# Altium schematic sheet 12-Power.SchDoc(12-Power)
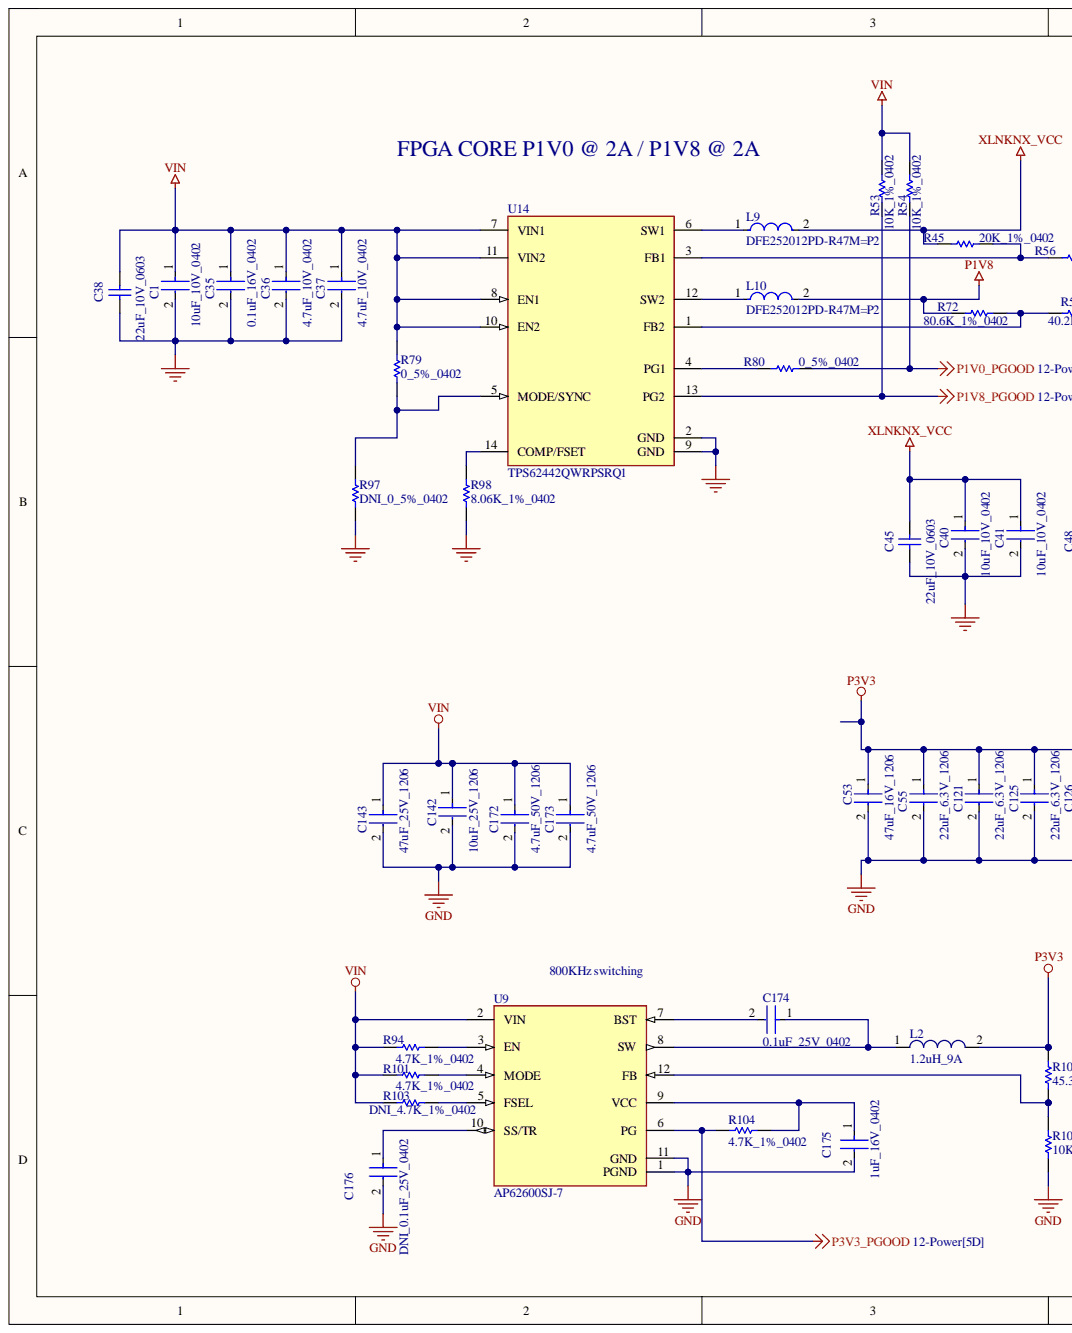
[diagram p0: 12-Power.SchDoc, left]
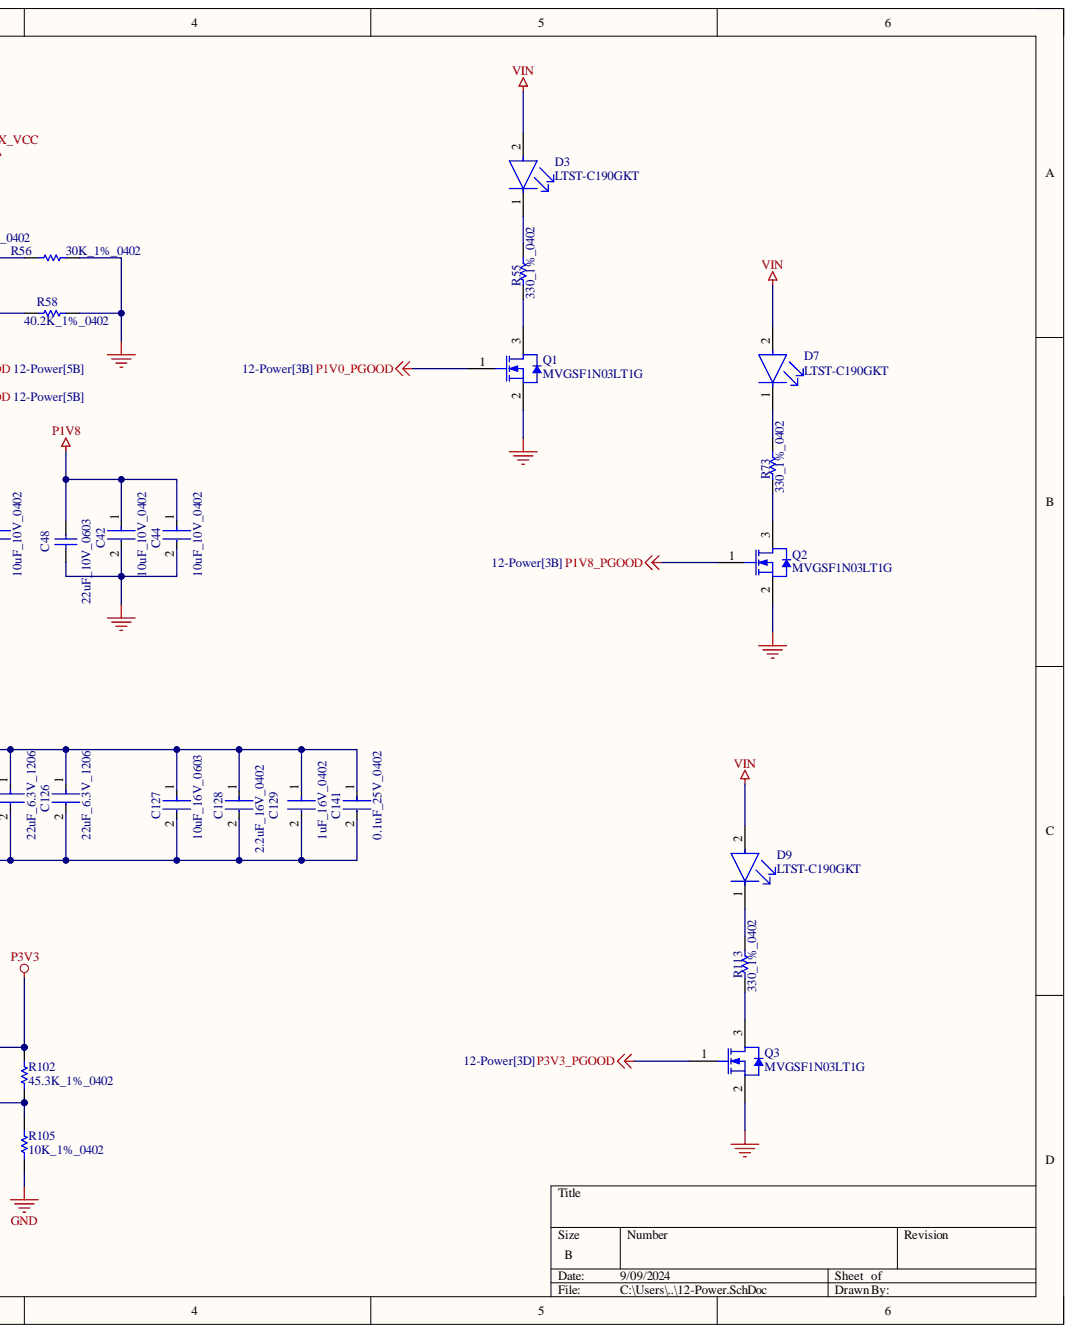
[diagram p1: 12-Power.SchDoc, right]

## Parts
C1  10uF_10V_0402  [FP-CL05A106MP5NUNC-MFG]
C35  0.1uF_16V_0402  [FP-C0402-BB-MFG]
C36  4.7uF_10V_0402  [FP-C1005-050-0_15-MFG]
C37  4.7uF_10V_0402  [FP-C1005-050-0_15-MFG]
C38  22uF_10V_0603  [CAPC1608X100X20ML10]
C40  10uF_10V_0402  [FP-CL05A106MP5NUNC-MFG]
C41  10uF_10V_0402  [FP-CL05A106MP5NUNC-MFG]
C42  10uF_10V_0402  [FP-CL05A106MP5NUNC-MFG]
C44  10uF_10V_0402  [FP-CL05A106MP5NUNC-MFG]
C45  22uF_10V_0603  [CAPC1608X100X20ML10]
C48  22uF_10V_0603  [CAPC1608X100X20ML10]
C53  47uF_16V_1206  [FP-C3216-160-0_2-MFG]
C55  22uF_6.3V_1206  [FP-1206-L_3_2_0_2-W_1_6_0-IPC_C]
C121  22uF_6.3V_1206  [FP-1206-L_3_2_0_2-W_1_6_0-IPC_C]
C125  22uF_6.3V_1206  [FP-1206-L_3_2_0_2-W_1_6_0-IPC_C]
C126  22uF_6.3V_1206  [FP-1206-L_3_2_0_2-W_1_6_0-IPC_C]
C127  10uF_16V_0603  [FP-0603-L_1_6_0_2-W_0_8_0-MFG]
C128  2.2uF_16V_0402  [FP-C1005-050-0_05-IPC_A]
C129  1uF_16V_0402  [FP-0402-L_1_0_0_05-W_0_5-IPC_A]
C141  0.1uF_25V_0402  [FP-0402-L_1_0_1-W_0_5_0_1-IPC_C]
C142  10uF_25V_1206  [FP-1206-L_3_2_0_2-W_1_6_0-IPC_A]
C143  47uF_25V_1206  [FP-C3216-160-0_2-MFG]
C172  4.7uF_50V_1206  [FP-GRM31C-0_2-e0_3_0_8-IPC_C]
C173  4.7uF_50V_1206  [FP-GRM31C-0_2-e0_3_0_8-IPC_C]
C174  0.1uF_25V_0402  [FP-0402-L_1_0_1-W_0_5_0_1-IPC_C]
C175  1uF_16V_0402  [FP-0402-L_1_0_0_05-W_0_5-IPC_A]
C176  DNI_0.1uF_25V_0402  [FP-0402-L_1_0_1-W_0_5_0_1-IPC_C]  (no BOM)
D3  LTST-C190GKT  [FP-LTST-C190GKT-MFG]
D7  LTST-C190GKT  [FP-LTST-C190GKT-MFG]
D9  LTST-C190GKT  [FP-LTST-C190GKT-MFG]
L2  1.2uH_9A  [WE-LHMI 7050]
L9  DFE252012PD-R47M=P2  [FP-DFE252012C-IPC_A]
L10  DFE252012PD-R47M=P2  [FP-DFE252012C-IPC_A]
Q1  MVGSF1N03LT1G  [ONSC-SOT-23-3-318-08_V]
Q2  MVGSF1N03LT1G  [ONSC-SOT-23-3-318-08_V]
Q3  MVGSF1N03LT1G  [ONSC-SOT-23-3-318-08_V]
R45  20K_1%_0402  [RESC1005X40X25ML10T10]
R53  10K_1%_0402  [RESC1005X40X25NL10T10]
R54  10K_1%_0402  [RESC1005X40X25NL10T10]
R55  330_1%_0402  [RESC1005X40X25NL10T10]
R56  30K_1%_0402  [RESC1005X40X25ML05T05]
R58  40.2K_1%_0402  [RESC1005X40X25ML05T10]
R72  80.6K_1%_0402  [RESC1005X40X25NL05T10]
R73  330_1%_0402  [RESC1005X40X25NL10T10]
R79  0_5%_0402  [RESC1005X40X25NL05T10]
R80  0_5%_0402  [RESC1005X40X25NL05T10]
R94  4.7K_1%_0402  [RESC1005X40X25NL10T10]
R97  DNI_0_5%_0402  [RESC1005X40X25NL05T10]  (no BOM)
R98  8.06K_1%_0402  [RESC1005X40X25ML05T05]
R101  4.7K_1%_0402  [RESC1005X40X25NL10T10]
R102  45.3K_1%_0402  [RESC1005X40X25ML05T10]
R103  DNI_4.7K_1%_0402  [RESC1005X40X25NL10T10]  (no BOM)
R104  4.7K_1%_0402  [RESC1005X40X25NL10T10]
R105  10K_1%_0402  [RESC1005X40X25NL10T10]
R113  330_1%_0402  [RESC1005X40X25NL10T10]
U9  AP62600SJ-7  [FP-V-QFN2030-12_Type_A-MFG]
U14  TPS62442QWRPSRQ1  [FP-RQR0014A-MFG]

## Nets
GND: C1.2 C35.2 C36.2 C37.2 C38.1 C40.2 C41.2 C42.2 C44.2 C45.1 C48.1 C53.2 C55.2 C121.2 C125.2 C126.2 C127.2 C128.2 C129.2 C141.2 C142.2 C143.2 C172.2 C173.2 C175.2 C176.2 Q1.2 Q2.2 Q3.2 R56.2 R58.2 R97.1 R98.1 R105.1 U9.1(PGND) U9.11(GND) U14.2(GND) U14.9(GND)
P1V0_PGOOD: Q1.1 R54.1 R80.2
P1V8: C42.1 C44.1 C48.2 L10.2 R72.1
P1V8_PGOOD: Q2.1 R53.1 U14.13(PG2)
P3V3: C53.1 C55.1 C121.1 C125.1 C126.1 C127.1 C128.1 C129.1 C141.1 L2.2 R102.2
P3V3_PGOOD: Q3.1 R104.2 U9.6(PG)
VIN: C1.1 C35.1 C36.1 C37.1 C38.2 C142.1 C143.1 C172.1 C173.1 D3.2 D7.2 D9.2 R53.2 R54.2 R79.2 R94.1 R101.1 R103.1 U9.2(VIN) U14.7(VIN1) U14.8(EN1) U14.10(EN2) U14.11(VIN2)
XLNKNX_VCC: C40.1 C41.1 C45.2 L9.2 R45.1
NetC174_1: C174.1 L2.1 U9.8(SW)
NetC174_2: C174.2 U9.7(BST)
NetC175_1: C175.1 R104.1 U9.9(VCC)
NetC176_1: C176.1 U9.10(SS/TR)
NetD3_1: D3.1 R55.2
NetD7_1: D7.1 R73.2
NetD9_1: D9.1 R113.2
NetL9_1: L9.1 U14.6(SW1)
NetL10_1: L10.1 U14.12(SW2)
NetQ1_3: Q1.3 R55.1
NetQ2_3: Q2.3 R73.1
NetQ3_3: Q3.3 R113.1
NetR45_2: R45.2 R56.1 U14.3(FB1)
NetR58_1: R58.1 R72.2 U14.1(FB2)
NetR79_1: R79.1 R97.2 U14.5(MODE/SYNC)
NetR80_1: R80.1 U14.4(PG1)
NetR94_2: R94.2 U9.3(EN)
NetR98_2: R98.2 U14.14(COMP/FSET)
NetR101_2: R101.2 U9.4(MODE)
NetR102_1: R102.1 R105.2 U9.12(FB)
NetR103_2: R103.2 U9.5(FSEL)

## Library data of the parts
C1 C40-C42 C44 = CMP-13271-000002-1: CAP CER 10UF 10V X5R 0402; Manufacturer: Samsung; Manufacturer Part Number: CL05A106MP5NUNC; Material: Ceramic; Temperature Coefficient: 15%; Tolerance: 20%; Automotive: No; Capacitance: 10uF; Category: Cap; Component Kind: Standard; ComponentLink2Description: Datasheet; ComponentLink2URL: http://www.samsungsem.com/kr/support/product-search/mlcc/__icsFiles/afieldfile/2019/05/10/19-CL05A106MP5NUNC.pdf; Device Class L1: Passive Components; Device Class L2: Capacitors; Device Class L3: Ceramic Capacitors; Digikey Description: CAP CER 10UF 10V X5R 0402; Height: 0.7mm; Ipc Land Pattern Name: CAPC10050X50; Lead Free: Yes; Library Name: Altium Content Vault; Octopart: https://octopart.com/cl05a106mp5nunc-samsung-22457395; Package: CL05A106MP5NUNC; RoHS: TRUE; Temperature: -55°C to +85°C; Temperature Characteristic: X5R; Temperature Range High: +85°C; Temperature Range Low: -55°C; Value: 10uF; Voltage Rating: 10V
C35 = CMP-1034-02068-3: CAP CER 0.1UF 16V 5% X7R 0402; Manufacturer: Kemet; Manufacturer Part Number: C0402C104J4RACTU; Capacitance: 0.10 μF; Material: Ceramic; Temperature Coefficient: 15%; Tolerance: 0.05; Value: 0.10 μF; Automotive: No; Category: Cap; Component Kind: Standard; ComponentLink2Description: Datasheet; ComponentLink2URL: https://content.kemet.com/datasheets/KEM_C1002_X7R_SMD.pdf; Device Class L1: Passive Components; Device Class L2: Capacitors; Device Class L3: Ceramic Capacitors; Digikey Description: CAP CER 0.1UF 16V 5% X7R 0402; Height: 0.55mm; Ipc Land Pattern Name: CAPC10050X50; Lead Free: Yes; Library Name: Altium Content Vault; Mouser Description: CAP CER 0.1UF 16V X7R 0402; Octopart: https://octopart.com/c0402c104j4ractu-kemet-12573893; Package: C0402-BB; RoHS: TRUE; Temperature: -55°C to +125°C; Temperature Characteristic: X7R; Temperature Range High: +125°C; Temperature Range Low: -55°C; Voltage Rating: 16 V
C36 C37 = CMP-2000-05997-2: CAP CER 4.7UF 10V X5R 0402; Dielectric Material: Ceramic; Tolerance: 10%; Capacitance: 4.7uF; Case Code (Imperial): 0402; Case Code (Metric): 1005; Case/Package: 0402; Component Kind: Standard; Dielectric: X5R; Lead Free: Lead Free; Length: 0.039inch; Library Name: Altium Content Vault; Max Operating Temperature: 85°C; Min Operating Temperature: -55°C; Mount: Surface Mount; Package Quantity: 10000; Packaging: Cut Tape; REACH SVHC: No SVHC; RoHS Compliant: Yes; Series: C; Thickness: 0.022inch; Voltage Rating: 10V; Voltage Rating (DC): 10V; Width: 0.02inch
C38 C45 C48 = CMP-2000-05441-1: Chip Capacitor, 22 uF, +/- 20%, 10 V, -55 to 85 degC, 0603 (1608 Metric), RoHS, Tape and Reel; Manufacturer: TDK; PCN Part Number: MLCCPartNumberChange30/Nov/2012; Capacitance: 22µF; Design Resources: 20WNon-IsolatedBuckLEDDriverUsingPLLNK460KG; Kits: 445-9014-KIT-ND-KITMLCC100PF-22UF060310-50V; Online Catalog: CSeries,GeneralPurpose; Other Names: 445-9077-1; Product Training Modules: CSeriesGeneralApplicationsSEAT,CCV,andTVCLDesignToolsGuidetoReplacinganElectrolyticCapacitorwithanMLCC; Temperature Coefficient: X5R; Voltage - Rated: 10V; Applications: GeneralPurpose; Category: Capacitors; Component Kind: Standard; Datasheet URL: http://download.siliconexpert.com/pdfs/2015/4/21/15/54/45/779/tdk_/manual/37024850441143360mlcc_commercial_general_en.pdf; Datasheet Version: Mar-15; Family: CeramicCapacitors; Features: -; Height - Seated (Max): -; Lead Spacing: -; Lead Style: -; Library Name: Altium Content Vault; Manufacturer URL: http://www.tdk.com/; Mounting Type: SurfaceMount,MLCC; Operating Temperature: -55degC~85degC; Package / Case: 0603(1608Metric); Package Description: 2-Pin Surface Mount Device, Body 1.6 x 0.8 mm; Package Reference: 603; Packaging: CutTape(CT); Ratings: -; RoHS Information: RoHSCertificate-MLCC; Series: C; Size / Dimension: 0.063"Lx0.031"W(1.60mmx0.80mm); Standard Package: 1; Thickness (Max): 0.035"(0.90mm); Tolerance: ±20%
C53 = CMP-2000-07442-2: Multilayer Ceramic Capacitors 47uF ±20% 16V X5R SMD 1206; Capacitance: 47uF; Case Code (Imperial): 1206; Case Code (Metric): 3216; Case/Package: 1206; Component Kind: Standard; Construction: Flat; Depth: 1.6mm; Dielectric: X5R; Dielectric Material: Ceramic; Height: 1.6mm; Lead Free: Lead Free; Length: 3.2mm; Library Name: Altium Content Vault; Max Operating Temperature: 85°C; Min Operating Temperature: -55°C; Mount: Surface Mount; Package Quantity: 1; Packaging: Tape and Reel; Radiation Hardening: No; REACH SVHC: No SVHC; Resistor Type: Ceramic; RoHS Compliant: Yes; Series: C; Termination: SMD/SMT; Thickness: 0.071inch; Tolerance: 20%; Voltage Rating: 16V; Voltage Rating (DC): 16V; Weight: 0.000571oz; Width: 0.063inch
C55 C121 C125 C126 = CMP-2000-05869-2: General Purpose Ceramic Capacitor, 1206, 22uF, 20%, X5R, 15%, 6.3V; Capacitance: 22uF; Case Code (Imperial): 1206; Case Code (Metric): 3216; Case/Package: 1206; Component Kind: Standard; Construction: Flat; Contact Plating: Tin; Depth: 1.6mm; Dielectric: X5R; Height: 0.07mm; Lead Free: Lead Free; Length: 3.2mm; Library Name: Altium Content Vault; Max Operating Temperature: 85°C; Min Operating Temperature: -55°C; Mount: Surface Mount; Number of Pins: 2; Package Quantity: 1; Packaging: Tape and Reel; Radiation Hardening: No; REACH SVHC: No SVHC; RoHS Compliant: Yes; Series: -; Termination: SMD/SMT; Thickness: 0.07inch; Tolerance: 20%; Voltage: 6.3V; Voltage Rating: 6.3V; Voltage Rating (DC): 6.3V; Width: 0.063inch
C127 = CMP-2000-06226-4: Multilayer Ceramic Capacitor 10uF 16V X5R 20% SMD 0603 T/R; Capacitance: 10uF; Case Code (Imperial): 0603; Case Code (Metric): 1608; Case/Package: 0603; Component Kind: Standard; Construction: Flat; Dielectric: X5R; Halogen Free: Halogen Free; Height: 0.8mm; Length: 0.063inch; Library Name: Altium Content Vault; Max Operating Temperature: 85°C; Min Operating Temperature: -55°C; Mount: Surface Mount; Package Quantity: 1; Packaging: Tape and Reel; RoHS Compliant: Yes; Series: M; Termination: SMD/SMT; Thickness: 0.031inch; Tolerance: 20%; Voltage Rating: 16V; Voltage Rating (DC): 16V; Width: 0.031inch
C128 = CMP-2000-05998-2: Multilayer Ceramic Capacitors 2.2μF ±10% 16V X5R SMD 0402; Capacitance: 2.2uF; Case Code (Imperial): 0402; Case Code (Metric): 1005; Case/Package: 0402; Component Kind: Standard; Depth: 0.5mm; Dielectric: X5R; Dielectric Material: Ceramic; Height: 0.5mm; Lead Free: Lead Free; Length: 1mm; Library Name: Altium Content Vault; Max Operating Temperature: 85°C; Min Operating Temperature: -55°C; Mount: Surface Mount; Package Quantity: 1; Packaging: Tape and Reel; REACH SVHC: No SVHC; Resistor Type: Ceramic; RoHS Compliant: Yes; Series: C; Termination: SMD/SMT; Thickness: 0.022inch; Tolerance: 10%; Voltage Rating: 16V; Voltage Rating (DC): 16V; Weight: 2.3E-05oz; Width: 0.02inch
C129 C175 = CMP-14477-000104-2: None; Capacitance: 1uF; Dielectric: X5R; Tolerance: 10%; Voltage Rating: 16V; Case Code (Imperial): 0402; Case Code (Metric): 1005; Case/Package: 0402; Component Kind: Standard; Construction: Flat; Depth: 0.5mm; Halogen Free: Halogen Free; Height: 0.5mm; Length: 1mm; Library Name: Altium Content Vault; Max Operating Temperature: 85°C; Min Operating Temperature: -55°C; Mount: Surface Mount; Number of Pins: 2; Package Quantity: 1; Packaging: Tape and Reel; Radiation Hardening: No; RoHS Compliant: Yes; Series: M; Simulation: EMK105BJ105_V-F; Termination: SMD/SMT; Thickness: 0.022inch; Voltage Rating (DC): 16V; Width: 0.02inch
C141 C174 C176 = CMP-2008-02519-2: General Purpose Ceramic Capacitor, 0402, 100nF, 10%, X7R, 15%, 25V; Capacitance: 100nF; Case Code (Imperial): 0402; Case Code (Metric): 1005; Case/Package: 0402; Construction: Flat; Depth: 0.5mm; Dielectric: X7R; Lead Free: Lead Free; Length: 1mm; Library Name: Altium Content Vault; Max Operating Temperature: 125°C; Min Operating Temperature: -55°C; Mount: Surface Mount; Number of Pins: 2; Package Quantity: 1; Packaging: Tape and Reel; Radiation Hardening: No; REACH SVHC: No SVHC; RoHS Compliant: Yes; Series: -; Termination: SMD/SMT; Thickness: 0.022inch; Tolerance: 10%; Voltage: 25V; Voltage Rating: 25V; Voltage Rating (DC): 25V; Width: 0.02inch
  C141 C174: Component Kind: Standard
C142 = CMP-04427-006943-1: General Purpose Ceramic Capacitor, 1206, 10uF, 20%, X5R, 15%, 25V; Dissipation Factor: 12.5%; Insulation Resistance: 10GR; Capacitance: 10uF; Case Code (Imperial): 1206; Case Code (Metric): 3216; Case/Package: 1206; Component Kind: Standard; Construction: Flat; Contact Plating: Tin; Depth: 1.6mm; Dielectric: X5R; Height: 0.07mm; Lead Free: Lead Free; Length: 3.2mm; Library Name: Altium Content Vault; Max Operating Temperature: 85°C; Min Operating Temperature: -55°C; Mount: Surface Mount; Number of Pins: 2; Package Quantity: 1; Packaging: Tape and Reel; Radiation Hardening: No; REACH SVHC: No SVHC; RoHS Compliant: Yes; Series: -; Termination: SMD/SMT; Thickness: 0.07inch; Tolerance: 20%; Voltage Rating: 25V; Voltage Rating (DC): 25V; Width: 1.6mm
C143 = CMP-2000-05397-3: Multilayer Ceramic Capacitors 47uF ±20% 25V X5R SMD 1206; Capacitance: 47uF; Case Code (Imperial): 1206; Case Code (Metric): 3216; Case/Package: 1206; Component Kind: Standard; Depth: 1.6mm; Dielectric: X5R; Dielectric Material: Ceramic; Height: 1.6mm; Lead Free: Lead Free; Length: 0.126inch; Library Name: Altium Content Vault; Max Operating Temperature: 85°C; Min Operating Temperature: -55°C; Mount: Surface Mount; Package Quantity: 1; Packaging: Tape and Reel; REACH SVHC: No SVHC; Resistor Type: Ceramic; RoHS Compliant: Yes; Series: C; Termination: SMD/SMT; Thickness: 0.071inch; Tolerance: 20%; Voltage: 25V; Voltage Rating: 25V; Voltage Rating (DC): 25V; Weight: 0.000571oz; Width: 0.063inch
C172 C173 = CMP-1037-04923-3: Chip Multilayer Ceramic Capacitors for General Purpose, 1206, 4.7uF, X7R, 15%, 10%, 50V; Capacitance: 4.7uF; Case Code (Imperial): 1206; Case Code (Metric): 3216; Case/Package: 1206; Component Kind: Standard; Depth: 1.6mm; Dielectric: X7R; Height: 1.6mm; Lead Free: Lead Free; Length: 3.2mm; Library Name: Altium Content Vault; Material: Ceramic; Max Operating Temperature: 125°C; Min Operating Temperature: -55°C; Mount: Surface Mount; Package Quantity: 2000; Packaging: Tape and Reel; Radiation Hardening: No; REACH SVHC: No SVHC; Termination: SMD/SMT; Thickness: 0.071inch; Tolerance: 10%; Voltage: 50V; Voltage Rating: 50V; Voltage Rating (DC): 50V; Width: 0.063inch
D3 D7 D9 = CMP-22017-000005-2: LED GREEN CLEAR CHIP SMD; Dominant Wavelength: 569nm; Forward Current: 30mA; Forward Voltage: 2.1V; Lens Color: Colorless; Luminous Intensity: 6mcd; Number of Elements: 1; Power Dissipation: 100mW; Reverse Voltage: 5V; Test Current: 10mA; Viewing Angle: 130°; Voltage: 2V; Wavelength: 565nm; Case Code (Imperial): 0603; Case Code (Metric): 1608; Case/Package: 0603; Color: Green; Component Kind: Standard; Depth: 0.8mm; Height: 0.8mm; Illumination Color: Green; Lead Free: Lead Free; Length: 1.6mm; Lens Style: Clear; Lens Transparency: Clear; Library Name: Altium Content Vault; Max Operating Temperature: 85°C; Min Operating Temperature: -55°C; Mount: Surface Mount; Number of LEDs: 1; Number of Pins: 2; Package Quantity: 1; Packaging: Tape and Reel; Power Rating: 100mW; Radiation Hardening: No; Width: 0.8mm
L2 = CMP-1353-00066-2: SMD Power Inductor WE-LHMI, L=1.2 µH; Manufacturer: Wuerth Elektronik; PartNumber: 74437349012; Inductance: 1.2 ÂµH; Component Kind: Standard; ComponentLink1Description: Manufacturer URL; ComponentLink1URL: http://www.we-online.com; ComponentLink2Description: Datasheet; ComponentLink2URL: http://katalog.we-online.de/kataloge/eisos/media/pdf/74437349012.pdf; Library Name: Altium Content Vault; PackageReference: WE-LHMI 7050
L9 L10 = CMP-06042-015149-1: Shielded Wirewound Inductor 0.47uH 20% 4000mA 0.027Ω 1008 (2520); Component Kind: Standard; Library Name: Altium Content Vault
Q1-Q3 = CMP-1058-00779-1: Power MOSFET, 30 V, 2.1 A, Single N-Channel, 3-Pin SOT-23, Pb-Free, Tape and Reel; Manufacturer: On Semiconductor; Channel Polarity: N Channel; Ciss Typ (pF): 140; Coss Typ (pF): 100; Crss Typ (pF): 40; ID Max (A): 2.1; V(BR)DSS Min (V): 30; VGS Max (V): 20; VGS(th) Max (V): 2.4; Component Kind: Standard; ComponentLink1Description: Manufacturer URL; ComponentLink1URL: http://www.onsemi.com/; ComponentLink2Description: Datasheet; ComponentLink2URL: http://www.onsemi.cn/pub_link/Collateral/MGSF1N03LT1-D.PDF; ComponentLink3Description: Package Specification; ComponentLink3URL: http://www.onsemi.cn/pub_link/Collateral/318-08.PDF; Configuration: Single; DatasheetVersion: Rev. 10; Library Name: Altium Content Vault; Mounting Technology: Surface Mount; Package Type: SOT-23-3; PackageDescription: 3-Pin Small Outline Transistor, Body 2.92 x 1.3 mm, Pitch 0.95 mm; PackageReference: SOT-23-3-318-08; PackageVersion: Rev. AP, 11/2009; Packing: Tape and Reel; PartNumber: MVGSF1N03LT1G; RoHS: Pb-Free
R45 = CMP-2002-05709-1: Manufacturer: TE Connectivity / AMP; Part Number: CRG0402F20K; Component Kind: Standard; Library Name: Altium Content Vault
R53 R54 R105 = CMP-2002-04694-1: Manufacturer: Bourns; Part Number: CR0402-FX-1002GLF; Component Kind: Standard; Library Name: Altium Content Vault
R55 R73 R113 = CMP-2002-05853-1: Manufacturer: Panasonic; Part Number: ERA-2AED331X; Component Kind: Standard; Library Name: Altium Content Vault
R56 = CMP-2002-00511-1: Manufacturer: Panasonic; Part Number: ERJ-2GEJ303X; Component Kind: Standard; Library Name: Altium Content Vault
R58 = CMP-2002-07676-1: Manufacturer: Yageo / Phycomp; Part Number: RC0402FR-0740K2L; Component Kind: Standard; Library Name: Altium Content Vault
R72 = CMP-2002-07812-1: Manufacturer: Yageo / Phycomp; Part Number: RC0402FR-0780K6L; Component Kind: Standard; Library Name: Altium Content Vault
R79 R80 R97 = CMP-2002-06457-1: Manufacturer: Panasonic; Part Number: ERJ-U020R00X; Library Name: Altium Content Vault
  R79 R80: Component Kind: Standard
R94 R101 R103 R104 = CMP-2002-05217-1: Manufacturer: Bourns; Part Number: CR0402-FX-4701GLF; Library Name: Altium Content Vault
  R94 R101 R104: Component Kind: Standard
R98 = CMP-2002-00253-3: Chip Resistor, 8.06 KOhm, +/- 1%, 63 mW, -55 to 155 degC, 0402 (1005 Metric), RoHS, Tape and Reel; Manufacturer: Basic Passives; PartNumber: CRCW04028K06FKED; Case\/Package: 0402; Power Rating: 63 mW; Voltage Rating: 50 V; Case Code (Imperial): 0402; Case Code (Metric): 1005; Component Kind: Standard; ComponentLink1Description: Manufacturer URL; ComponentLink1URL: http://www.vishay.com/; ComponentLink2Description: Datasheet; ComponentLink2URL: http://www.vishay.com/docs/20035/dcrcwe3.pdf; DatasheetVersion: 06/2012; Height: 0.35 mm; Length: 1 mm; Library Name: Altium Content Vault; Max Operating Temperature: 155 degC; Min Operating Temperature: -55 degC; Mount: Surface Mount; Mounting Technology: Surface Mount; Package Quantity: 10000; PackageDescription: 2-Pin Surface Mount Device, Body 1 x 0.5 mm; PackageReference: 0402; Packaging: Tape and Reel; Pins: 2; Radiation Hardening: No; REACH SVHC: Unknown; Resistance: 8.06 KOhm; RoHSCompliant: true; Tolerance: 1%; Weight: 2.3E-05oz; Width: 0.5 mm
R102 = CMP-2002-07692-1: Manufacturer: Yageo / Phycomp; Part Number: RC0402FR-0745K3L; Component Kind: Standard; Library Name: Altium Content Vault
U9 = CMP-12757-000022-1: DCDC CONV HV BUCK,V-QFN2030-12; Component Kind: Standard; Library Name: Altium Content Vault; RoHS Compliant: Yes
U14 = CMP-04918-000654-1: Adjustable-Frequency Dual Step-Down Converter, 2.7-6V VIN, 0.6-5.5V 2A VOUT, VQFN-HR14; Component Kind: Standard; Library Name: Altium Content Vault
